# Altium schematic sheet MainBoard.SchDoc
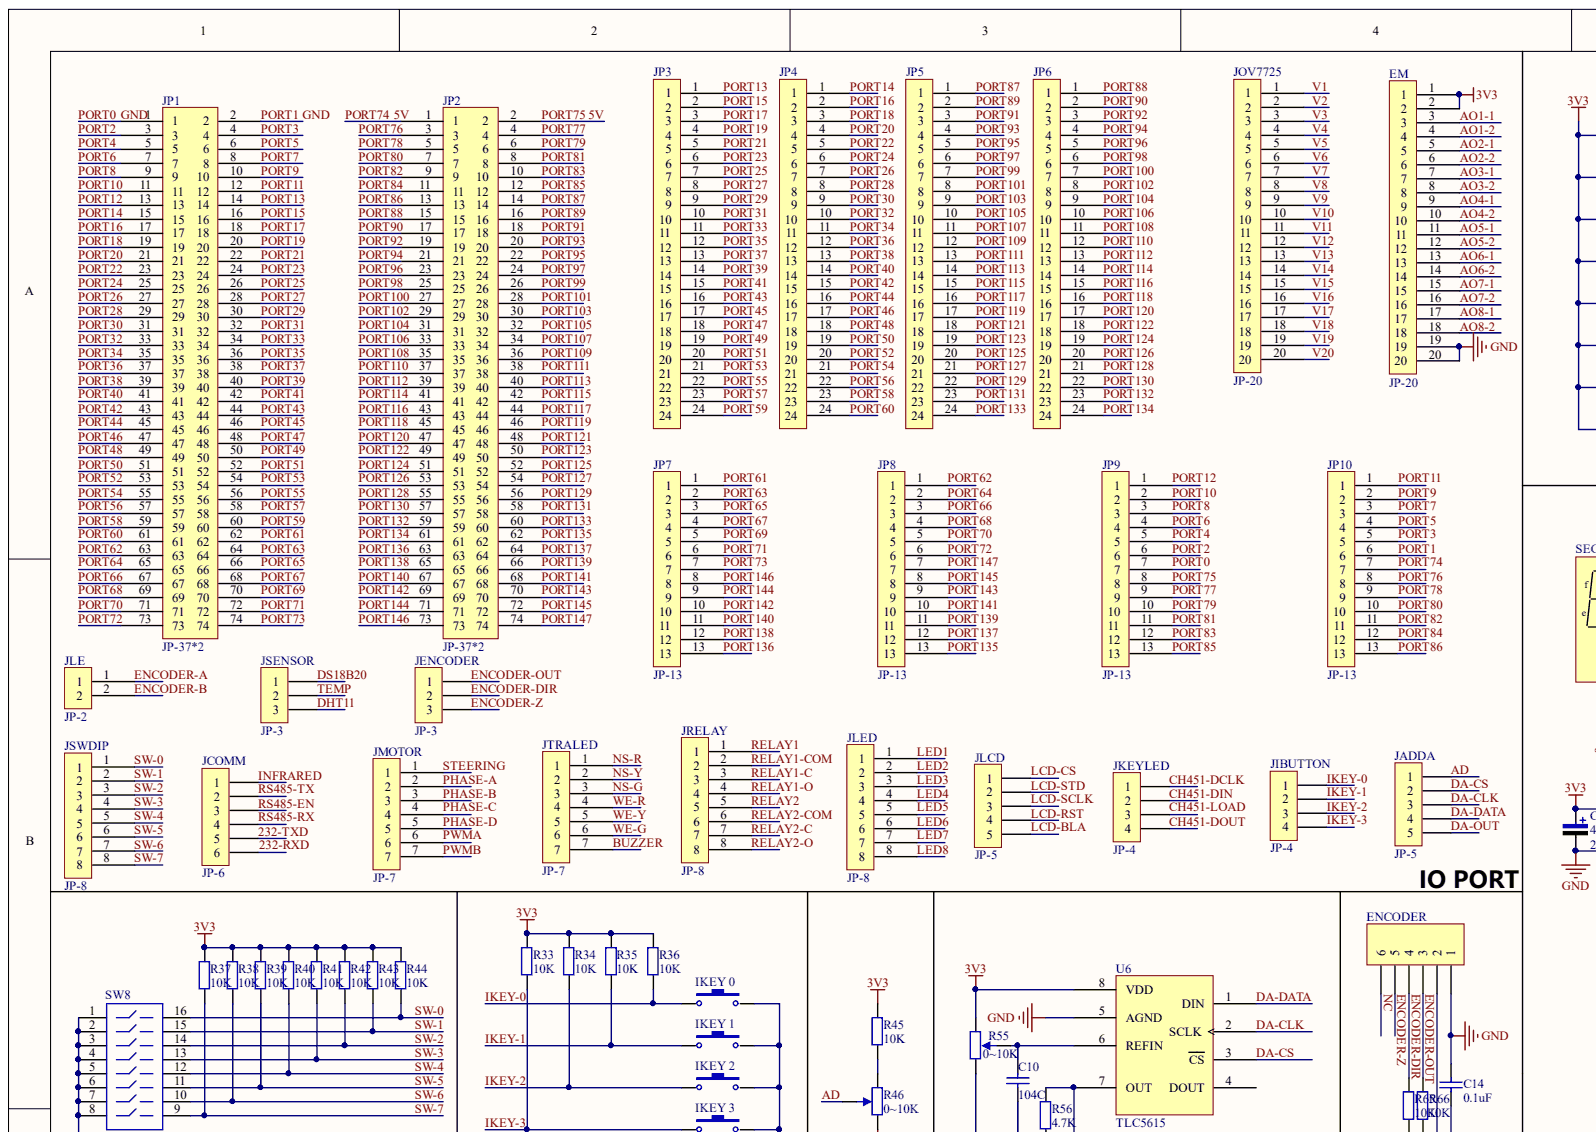
[diagram p0: MainBoard.SchDoc, top left]
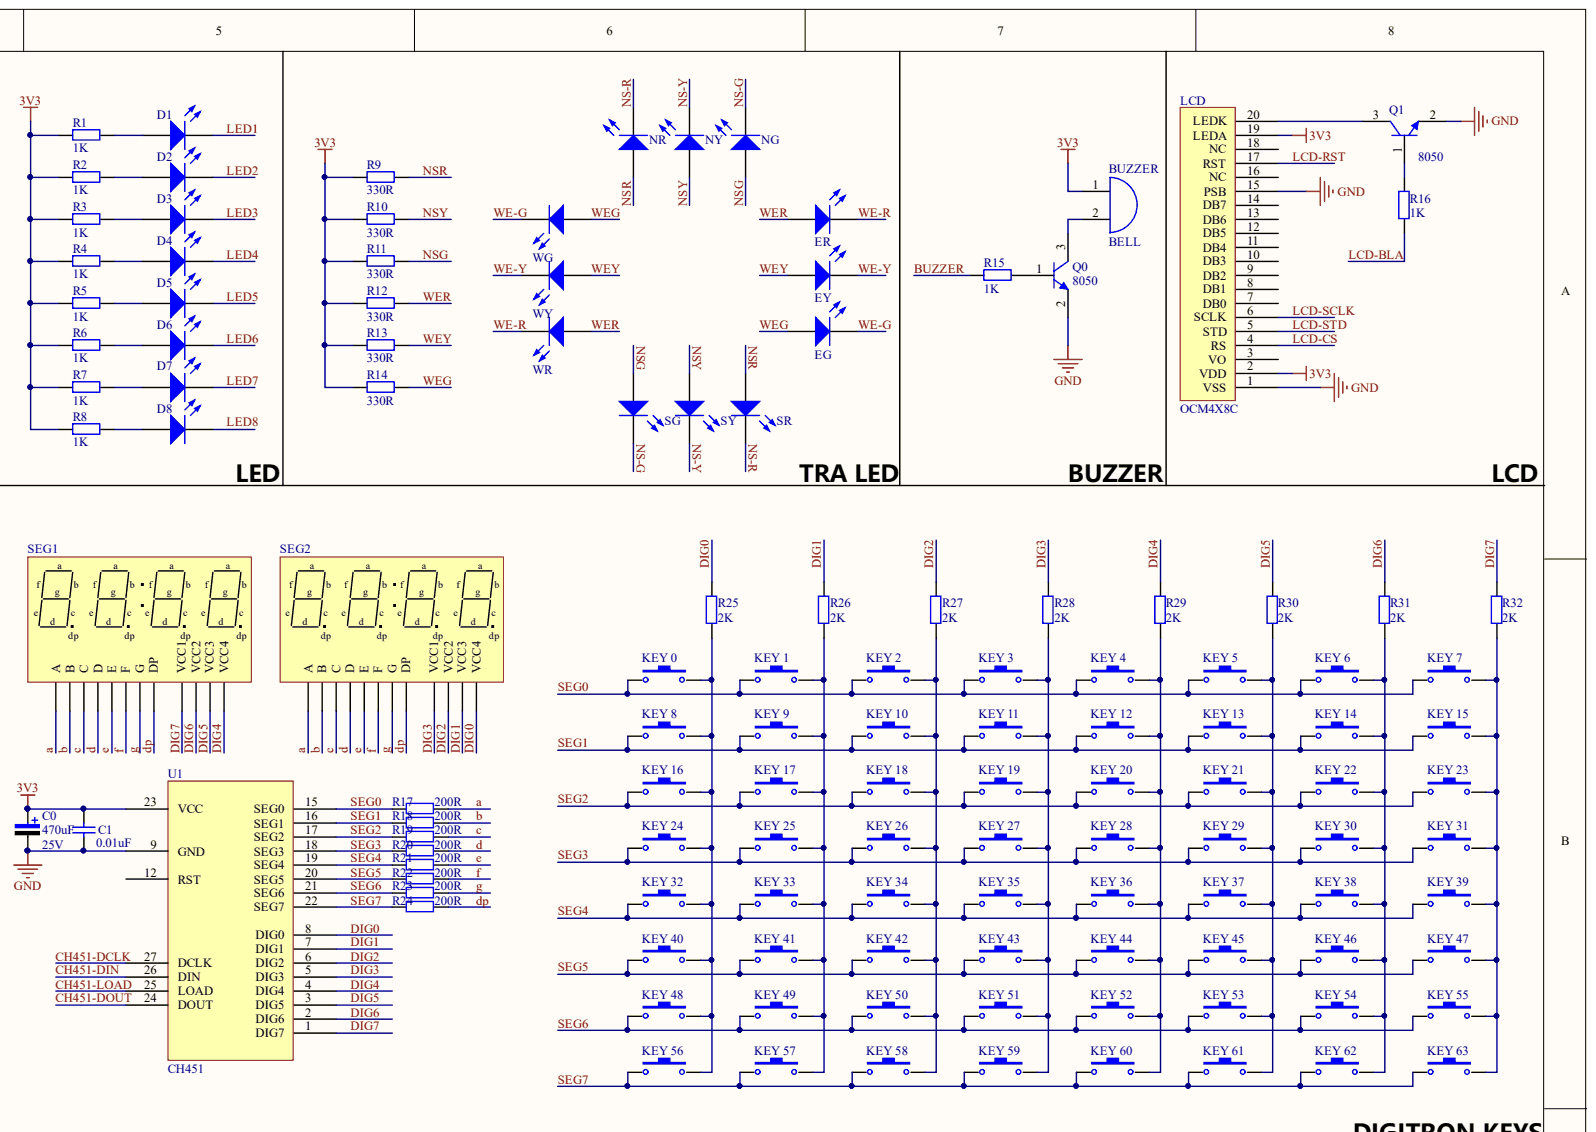
[diagram p1: MainBoard.SchDoc, top right]
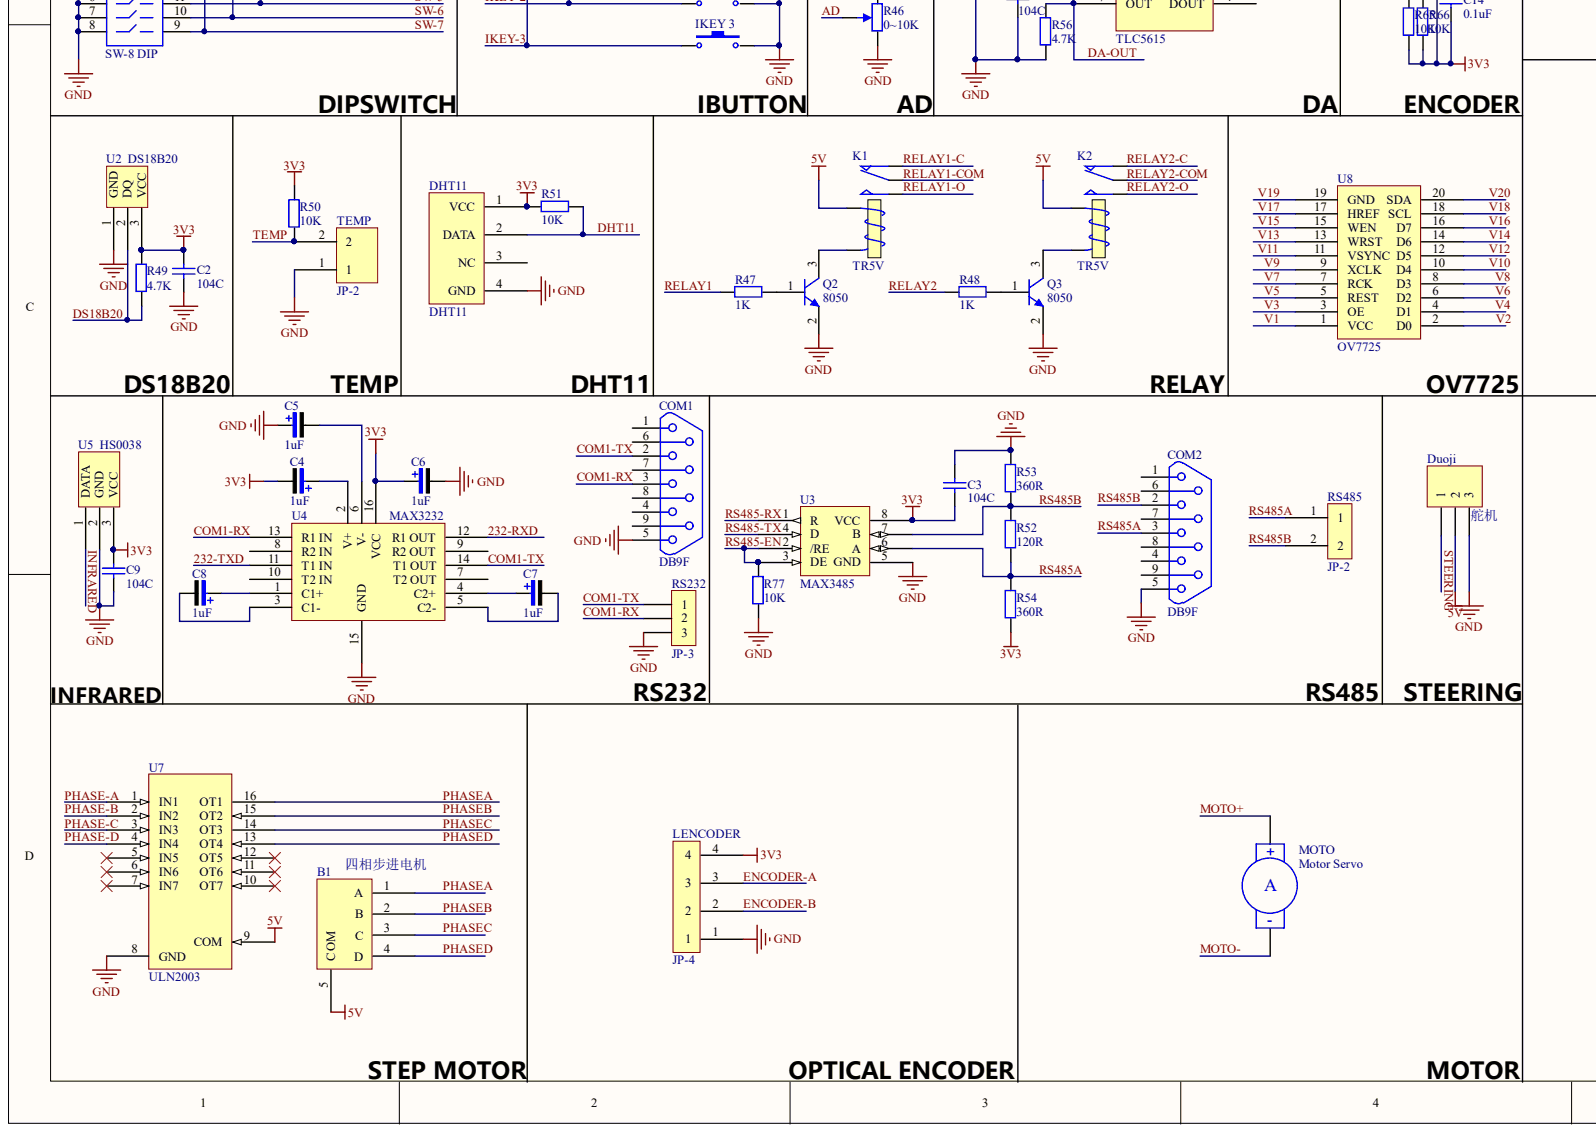
[diagram p2: MainBoard.SchDoc, bottom left]
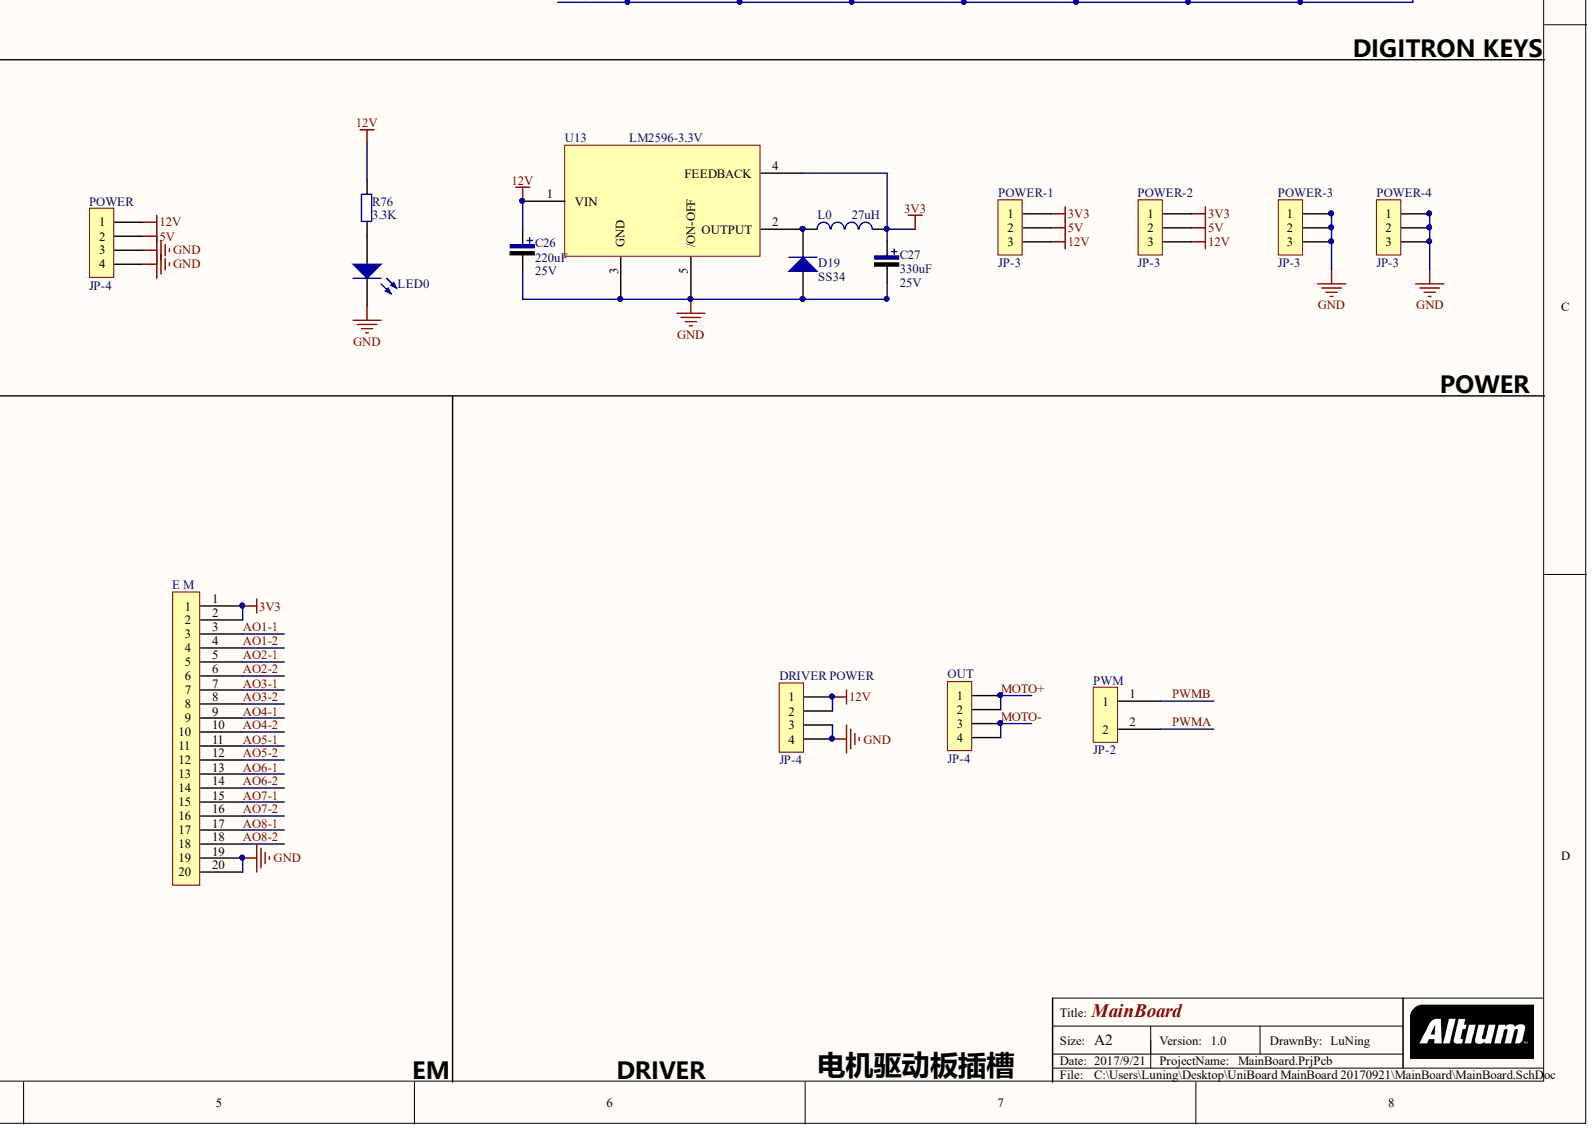
[diagram p3: MainBoard.SchDoc, bottom right]

## Parts
B1  四相步进电机  [SIP5]
BUZZER  BELL  [BUZZ0.25]
C0  value 470uF  Vol: 25V  [Cap_10uf]  (hidden comment: Cap Pol_1)
C1  value 0.01uF  [C-RAD-0805]  (hidden comment: Cap)
C2  value 104C  [C-RAD-0805]  (hidden comment: Cap)
C3  value 104C  [C-RAD-0805]  (hidden comment: Cap)
C4  value 1uF  [钽电容-A]  (hidden comment: Cap Pol)
C5  value 1uF  [钽电容-A]  (hidden comment: Cap Pol)
C6  value 1uF  [钽电容-A]  (hidden comment: Cap Pol)
C7  value 1uF  [钽电容-A]  (hidden comment: Cap Pol)
C8  value 1uF  [钽电容-A]  (hidden comment: Cap Pol)
C9  value 104C  [C-RAD-0805]  (hidden comment: Cap)
C10  value 104C  [C-RAD-0805]  (hidden comment: Cap)
C14  value 0.1uF  [C-RAD-0805]  (hidden comment: Cap)
C26  value 220uF  Vol: 25V  [Cap_10uf]  (hidden comment: Cap Pol_1)
C27  value 330uF  Vol: 25V  [Cap_10uf]  (hidden comment: Cap Pol_1)
COM1  DB9F  [DB9F]
COM2  DB9F  [DB9F]
D1  LED  [LED_0805]
D2  LED  [LED_0805]
D3  LED  [LED_0805]
D4  LED  [LED_0805]
D5  LED  [LED_0805]
D6  LED  [LED_0805]
D7  LED  [LED_0805]
D8  LED  [LED_0805]
D19  SS34  [DIODE-SMB]
DHT11  DHT11  [SIP4]
DRIVER_POWER  JP-4  [SIP4]
Duoji  舵机  [SIP3-A]
E_M  JP-20  [SIP20 - 2]  (value not drawn)
EG  LED  [LED_0805]  (value not drawn)
EM  JP-20  [K2-20 - S - D -7]
ENCODER  JP-6  [SIP6]  (value not drawn)
ER  LED  [LED_0805]  (value not drawn)
EY  LED  [LED_0805]  (value not drawn)
IKEY_0  Button -4  [SW-4]  (value not drawn)
IKEY_1  Button -4  [SW-4]  (value not drawn)
IKEY_2  Button -4  [SW-4]  (value not drawn)
IKEY_3  Button -4  [SW-4]  (value not drawn)
JADDA  JP-5  [K2-5 - S - 7]
JCOMM  JP-6  [K2-6 - S - 7]
JENCODER  JP-3  [K2-3 - S - 7]
JIBUTTON  JP-4  [K2-4 - S - 7]
JKEYLED  JP-4  [K2-4 - S - 7]
JLCD  JP-5  [K2-5 - S - 7]
JLE  JP-2  [K2-2 - S - 7]
JLED  JP-8  [K2-8 - S - 7]
JMOTOR  JP-7  [K2-7 - S - 7]
JOV7725  JP-20  [K2-20 - S - D -7]
JP1  JP-37*2  [SIP74- 2]
JP2  JP-37*2  [SIP74- 2]
JP3  JP-24  [K2-24 - S - 7]  (value not drawn)
JP4  JP-24  [K2-24 - S - 7]  (value not drawn)
JP5  JP-24  [K2-24 - S - 7]  (value not drawn)
JP6  JP-24  [K2-24 - S - 7]  (value not drawn)
JP7  JP-13  [K2-13 - S - 7]
JP8  JP-13  [K2-13 - S - 7]
JP9  JP-13  [K2-13 - S - 7]
JP10  JP-13  [K2-13 - S - 7]
JRELAY  JP-8  [K2-8 - S - 7]
JSENSOR  JP-3  [K2-3 - S - 7]
JSWDIP  JP-8  [K2-8 - S - 7]
JTRALED  JP-7  [K2-7 - S - 7]
K1  TR5V  [RELAY-5]
K2  TR5V  [RELAY-5]
KEY_0  0  [SW-4]
KEY_1  0  [SW-4]  (value not drawn)
KEY_2  0  [SW-4]  (value not drawn)
KEY_3  0  [SW-4]  (value not drawn)
KEY_4  0  [SW-4]  (value not drawn)
KEY_5  0  [SW-4]  (value not drawn)
KEY_6  0  [SW-4]  (value not drawn)
KEY_7  0  [SW-4]  (value not drawn)
KEY_8  0  [SW-4]  (value not drawn)
KEY_9  0  [SW-4]  (value not drawn)
KEY_10  0  [SW-4]  (value not drawn)
KEY_11  0  [SW-4]  (value not drawn)
KEY_12  0  [SW-4]  (value not drawn)
KEY_13  0  [SW-4]  (value not drawn)
KEY_14  0  [SW-4]  (value not drawn)
KEY_15  0  [SW-4]  (value not drawn)
KEY_16  0  [SW-4]  (value not drawn)
KEY_17  0  [SW-4]  (value not drawn)
KEY_18  0  [SW-4]  (value not drawn)
KEY_19  0  [SW-4]  (value not drawn)
KEY_20  0  [SW-4]  (value not drawn)
KEY_21  0  [SW-4]  (value not drawn)
KEY_22  0  [SW-4]  (value not drawn)
KEY_23  0  [SW-4]  (value not drawn)
KEY_24  0  [SW-4]  (value not drawn)
KEY_25  0  [SW-4]  (value not drawn)
KEY_26  0  [SW-4]  (value not drawn)
KEY_27  0  [SW-4]  (value not drawn)
KEY_28  0  [SW-4]  (value not drawn)
KEY_29  0  [SW-4]  (value not drawn)
KEY_30  0  [SW-4]  (value not drawn)
KEY_31  0  [SW-4]  (value not drawn)
KEY_32  0  [SW-4]  (value not drawn)
KEY_33  0  [SW-4]  (value not drawn)
KEY_34  0  [SW-4]  (value not drawn)
KEY_35  0  [SW-4]  (value not drawn)
KEY_36  0  [SW-4]  (value not drawn)
KEY_37  0  [SW-4]  (value not drawn)
KEY_38  0  [SW-4]  (value not drawn)
KEY_39  0  [SW-4]  (value not drawn)
KEY_40  0  [SW-4]  (value not drawn)
KEY_41  0  [SW-4]  (value not drawn)
KEY_42  0  [SW-4]  (value not drawn)
KEY_43  0  [SW-4]  (value not drawn)
KEY_44  0  [SW-4]  (value not drawn)
KEY_45  0  [SW-4]  (value not drawn)
KEY_46  0  [SW-4]  (value not drawn)
KEY_47  0  [SW-4]  (value not drawn)
KEY_48  0  [SW-4]  (value not drawn)
KEY_49  0  [SW-4]  (value not drawn)
KEY_50  0  [SW-4]  (value not drawn)
KEY_51  0  [SW-4]  (value not drawn)
KEY_52  0  [SW-4]  (value not drawn)
KEY_53  0  [SW-4]  (value not drawn)
KEY_54  0  [SW-4]  (value not drawn)
KEY_55  0  [SW-4]  (value not drawn)
KEY_56  0  [SW-4]  (value not drawn)
KEY_57  0  [SW-4]  (value not drawn)
KEY_58  0  [SW-4]  (value not drawn)
KEY_59  0  [SW-4]  (value not drawn)
KEY_60  0  [SW-4]  (value not drawn)
KEY_61  0  [SW-4]  (value not drawn)
KEY_62  0  [SW-4]  (value not drawn)
KEY_63  0  [SW-4]  (value not drawn)
L0  value 27uH  [Inductor]  (hidden comment: Inductor_1)
LCD  OCM4X8C  [SIP20]
LED0  LED  [LED_0805]
LENCODER  JP-4  [SIP4-A]
MOTO  Motor Servo  [接线柱]
NG  LED  [LED_0805]  (value not drawn)
NR  LED  [LED_0805]  (value not drawn)
NY  LED  [LED_0805]  (value not drawn)
OUT  JP-4  [SIP4]
POWER  JP-4  [SIP4-L]
POWER-1  JP-3  [K2-3 - S - s]
POWER-2  JP-3  [K2-3 - S - s]
POWER-3  JP-3  [K2-3 - S - s]
POWER-4  JP-3  [K2-3 - S - s]
PWM  JP-2  [SIP2]
Q0  8050  [SOT23_N]
Q1  8050  [SOT23_N]
Q2  8050  [SOT23_N]
Q3  8050  [SOT23_N]
R1  value 1K  [0805]  (hidden comment: resistor)
R2  value 1K  [0805]  (hidden comment: resistor)
R3  value 1K  [0805]  (hidden comment: resistor)
R4  value 1K  [0805]  (hidden comment: resistor)
R5  value 1K  [0805]  (hidden comment: resistor)
R6  value 1K  [0805]  (hidden comment: resistor)
R7  value 1K  [0805]  (hidden comment: resistor)
R8  value 1K  [0805]  (hidden comment: resistor)
R9  value 330R  [0805]  (hidden comment: resistor)
R10  value 330R  [0805]  (hidden comment: resistor)
R11  value 330R  [0805]  (hidden comment: resistor)
R12  value 330R  [0805]  (hidden comment: resistor)
R13  value 330R  [0805]  (hidden comment: resistor)
R14  value 330R  [0805]  (hidden comment: resistor)
R15  value 1K  [0805]  (hidden comment: resistor)
R16  value 1K  [0805]  (hidden comment: resistor)
R17  value 200R  [0805]  (hidden comment: resistor)
R18  value 200R  [0805]  (hidden comment: resistor)
R19  value 200R  [0805]  (hidden comment: resistor)
R20  value 200R  [0805]  (hidden comment: resistor)
R21  value 200R  [0805]  (hidden comment: resistor)
R22  value 200R  [0805]  (hidden comment: resistor)
R23  value 200R  [0805]  (hidden comment: resistor)
R24  value 200R  [0805]  (hidden comment: resistor)
R25  value 2K  [0805]  (hidden comment: resistor)
R26  value 2K  [0805]  (hidden comment: resistor)
R27  value 2K  [0805]  (hidden comment: resistor)
R28  value 2K  [0805]  (hidden comment: resistor)
R29  value 2K  [0805]  (hidden comment: resistor)
R30  value 2K  [0805]  (hidden comment: resistor)
R31  value 2K  [0805]  (hidden comment: resistor)
R32  value 2K  [0805]  (hidden comment: resistor)
R33  value 10K  [0805]  (hidden comment: resistor)
R34  value 10K  [0805]  (hidden comment: resistor)
R35  value 10K  [0805]  (hidden comment: resistor)
R36  value 10K  [0805]  (hidden comment: resistor)
R37  value 10K  [0805]  (hidden comment: resistor)
R38  value 10K  [0805]  (hidden comment: resistor)
R39  value 10K  [0805]  (hidden comment: resistor)
R40  value 10K  [0805]  (hidden comment: resistor)
R41  value 10K  [0805]  (hidden comment: resistor)
R42  value 10K  [0805]  (hidden comment: resistor)
R43  value 10K  [0805]  (hidden comment: resistor)
R44  value 10K  [0805]  (hidden comment: resistor)
R45  value 10K  [0805]  (hidden comment: resistor)
R46  value 0~10K  [SIP3 - resadj]  (hidden comment: resistor -adj)
R47  value 1K  [0805]  (hidden comment: resistor)
R48  value 1K  [0805]  (hidden comment: resistor)
R49  value 4.7K  [0805]  (hidden comment: resistor)
R50  value 10K  [0805]  (hidden comment: resistor)
R51  value 10K  [0805]  (hidden comment: resistor)
R52  value 120R  [0805]  (hidden comment: resistor)
R53  value 360R  [0805]  (hidden comment: resistor)
R54  value 360R  [0805]  (hidden comment: resistor)
R55  value 0~10K  [SIP3 - resadj]  (hidden comment: resistor -adj)
R56  value 4.7K  [0805]  (hidden comment: resistor)
R65  value 10K  [0805]  (hidden comment: resistor)
R66  value 10K  [0805]  (hidden comment: resistor)
R76  value 3.3K  [0805]  (hidden comment: resistor)
R77  value 10K  [0805]  (hidden comment: resistor)
RS232  JP-3  [接线柱 - 3]
RS485  JP-2  [接线柱 - 2]
SEG1  DPY_7-SEG_DPx4_2  [LED_8.8.:8.8.]  (value not drawn)
SEG2  DPY_7-SEG_DPx4_2  [LED_8.8.:8.8.]  (value not drawn)
SG  LED  [LED_0805]  (value not drawn)
SR  LED  [LED_0805]
SW8  SW-8 DIP  [SW-8 DIP]
SY  LED  [LED_0805]  (value not drawn)
TEMP  JP-2  [SIP2]
U1  CH451  [SOP28]
U2  DS18B20  [DS18B20]
U3  MAX3485  [SOP8_N]
U4  MAX3232  [sop16]
U5  HS0038  [HS0038]
U6  TLC5615  [SOP8_N]
U7  ULN2003  [sop16]
U8  OV7725  [SIP20 - OV7725]
U13  LM2596-3.3V  [TO-263-5]
WG  LED  [LED_0805]  (value not drawn)
WR  LED  [LED_0805]  (value not drawn)
WY  LED  [LED_0805]  (value not drawn)

## Nets
3V3: BUZZER.1 C0.1 C1.2 C2.2 C3.1 C4.2 C6.1 C9.2 C14.1 C27.1 DHT11.1(VCC) E_M.1 E_M.2 EM.1 EM.2 ENCODER.2 L0.2 LCD.2(VDD) LCD.19(LEDA) LENCODER.4 POWER-1.1 POWER-2.1 R1.1 R2.1 R3.1 R4.1 R5.1 R6.1 R7.1 R8.1 R9.1 R10.1 R11.1 R12.1 R13.1 R14.1 R33.2 R34.2 R35.2 R36.2 R37.2 R38.2 R39.2 R40.2 R41.2 R42.2 R43.2 R44.2 R45.2 R49.2 R50.2 R51.2 R54.1 R55.3 R65.2 R66.2 U1.23(VCC) U2.3 U3.8(VCC) U4.16(VCC) U5.3 U6.8(VDD) U13.4(FEEDBACK)
12V: C26.1 DRIVER_POWER.1 DRIVER_POWER.2 POWER.1 POWER-1.3 POWER-2.3 R76.1 U13.1(VIN)
5V: B1.5(COM) Duoji.2 JP2.1 JP2.2 JP9.8 JP10.7 K1.2 K2.2 POWER.2 POWER-1.2 POWER-2.2 U7.9(COM)
232-RXD: JCOMM.6 U4.12(R1_OUT)
232-TXD: JCOMM.5 U4.11(T1_IN)
A: R17.2 SEG1.11(A) SEG2.11(A)
AD: JADDA.1 R46.2
AO1-1: E_M.3 EM.3
AO1-2: E_M.4 EM.4
AO2-1: E_M.5 EM.5
AO2-2: E_M.6 EM.6
AO3-1: E_M.7 EM.7
AO3-2: E_M.8 EM.8
AO4-1: E_M.9 EM.9
AO4-2: E_M.10 EM.10
AO5-1: E_M.11 EM.11
AO5-2: E_M.12 EM.12
AO6-1: E_M.13 EM.13
AO6-2: E_M.14 EM.14
AO7-1: E_M.15 EM.15
AO7-2: E_M.16 EM.16
AO8-1: E_M.17 EM.17
AO8-2: E_M.18 EM.18
B: R18.2 SEG1.7(B) SEG2.7(B)
BUZZER: JTRALED.7 R15.1
C: R19.2 SEG1.4(C) SEG2.4(C)
CH451-DCLK: JKEYLED.1 U1.27(DCLK)
CH451-DIN: JKEYLED.2 U1.26(DIN)
CH451-DOUT: JKEYLED.4 U1.24(DOUT)
CH451-LOAD: JKEYLED.3 U1.25(LOAD)
COM1-RX: COM1.3 RS232.2 U4.13(R1_IN)
COM1-TX: COM1.2 RS232.1 U4.14(T1_OUT)
D: R20.2 SEG1.2(D) SEG2.2(D)
DA-CLK: JADDA.3 U6.2(SCLK)
DA-CS: JADDA.2 U6.3(CS)
DA-DATA: JADDA.4 U6.1(DIN)
DA-OUT: JADDA.5 R56.2 U6.7(OUT)
DHT11: DHT11.2(DATA) JSENSOR.3 R51.1
DIG0: R25.2 SEG2.6(VCC4) U1.8(DIG0)
DIG1: R26.2 SEG2.8(VCC3) U1.7(DIG1)
DIG2: R27.2 SEG2.9(VCC2) U1.6(DIG2)
DIG3: R28.2 SEG2.12(VCC1_dp) U1.5(DIG3)
DIG4: R29.2 SEG1.6(VCC4) U1.4(DIG4)
DIG5: R30.2 SEG1.8(VCC3) U1.3(DIG5)
DIG6: R31.2 SEG1.9(VCC2) U1.2(DIG6)
DIG7: R32.2 SEG1.12(VCC1) U1.1(DIG7)
DP: R24.2 SEG1.3(DP) SEG2.3(DP)
DS18B20: JSENSOR.1 R49.1 U2.2
E: R21.2 SEG1.1(E) SEG2.1(E)
ENCODER-A: JLE.1 LENCODER.3
ENCODER-B: JLE.2 LENCODER.2
ENCODER-DIR: ENCODER.4 JENCODER.2 R65.1
ENCODER-OUT: ENCODER.3 JENCODER.1 R66.1
ENCODER-Z: ENCODER.5 JENCODER.3
F: R22.2 SEG1.10(F) SEG2.10(F)
G: R23.2 SEG1.5(G) SEG2.5(G)
GND: C0.2 C1.1 C2.1 C3.2 C5.1 C6.2 C9.1 C10.1 C14.2 C26.2 C27.2 COM1.5 COM2.5 D19.1 DHT11.4(GND) DRIVER_POWER.3 DRIVER_POWER.4 Duoji.3 E_M.19 E_M.20 EM.19 EM.20 ENCODER.1 IKEY_0.2 IKEY_1.2 IKEY_2.2 IKEY_3.2 JP1.1 JP1.2 JP9.7 JP10.6 LCD.1(VSS) LCD.15(PSB) LED0.2 LENCODER.1 POWER.3 POWER.4 POWER-3.1 POWER-3.2 POWER-3.3 POWER-4.1 POWER-4.2 POWER-4.3 Q0.2 Q1.2 Q2.2 Q3.2 R46.3 R53.2 R55.1 R56.1 R77.1 RS232.3 SW8.1 SW8.2 SW8.3 SW8.4 SW8.5 SW8.6 SW8.7 SW8.8 TEMP.1 U1.9(GND) U2.1 U3.5(GND) U4.15(GND) U5.2 U6.5(AGND) U7.8(GND) U13.3(GND) U13.5(/ON-OFF)
IKEY-0: IKEY_0.1 JIBUTTON.1 R36.1
IKEY-1: IKEY_1.1 JIBUTTON.2 R35.1
IKEY-2: IKEY_2.1 JIBUTTON.3 R34.1
IKEY-3: IKEY_3.1 JIBUTTON.4 R33.1
INFRARED: JCOMM.1 U5.1
LCD-BLA: JLCD.5 R16.1
LCD-CS: JLCD.1 LCD.4(RS)
LCD-RST: JLCD.4 LCD.17(RST)
LCD-SCLK: JLCD.3 LCD.6(SCLK)
LCD-STD: JLCD.2 LCD.5(STD)
LED1: D1.2 JLED.1
LED2: D2.2 JLED.2
LED3: D3.2 JLED.3
LED4: D4.2 JLED.4
LED5: D5.2 JLED.5
LED6: D6.2 JLED.6
LED7: D7.2 JLED.7
LED8: D8.2 JLED.8
MOTO+: MOTO.1 OUT.1 OUT.2
MOTO-: MOTO.2 OUT.3 OUT.4
NC: ENCODER.6
NS-G: JTRALED.3 NG.2 SG.2
NS-R: JTRALED.1 NR.2 SR.2
NS-Y: JTRALED.2 NY.2 SY.2
NSG: NG.1 R11.2 SG.1
NSR: NR.1 R9.2 SR.1
NSY: NY.1 R10.2 SY.1
PHASE-A: JMOTOR.2 U7.1(IN1)
PHASE-B: JMOTOR.3 U7.2(IN2)
PHASE-C: JMOTOR.4 U7.3(IN3)
PHASE-D: JMOTOR.5 U7.4(IN4)
PHASEA: B1.1(A) U7.16(OT1)
PHASEB: B1.2(B) U7.15(OT2)
PHASEC: B1.3(C) U7.14(OT3)
PHASED: B1.4(D) U7.13(OT4)
PORT2: JP1.3 JP9.6
PORT3: JP1.4 JP10.5
PORT4: JP1.5 JP9.5
PORT5: JP1.6 JP10.4
PORT6: JP1.7 JP9.4
PORT7: JP1.8 JP10.3
PORT8: JP1.9 JP9.3
PORT9: JP1.10 JP10.2
PORT10: JP1.11 JP9.2
PORT11: JP1.12 JP10.1
PORT12: JP1.13 JP9.1
PORT13: JP1.14 JP3.1
PORT14: JP1.15 JP4.1
PORT15: JP1.16 JP3.2
PORT16: JP1.17 JP4.2
PORT17: JP1.18 JP3.3
PORT18: JP1.19 JP4.3
PORT19: JP1.20 JP3.4
PORT20: JP1.21 JP4.4
PORT21: JP1.22 JP3.5
PORT22: JP1.23 JP4.5
PORT23: JP1.24 JP3.6
PORT24: JP1.25 JP4.6
PORT25: JP1.26 JP3.7
PORT26: JP1.27 JP4.7
PORT27: JP1.28 JP3.8
PORT28: JP1.29 JP4.8
PORT29: JP1.30 JP3.9
PORT30: JP1.31 JP4.9
PORT31: JP1.32 JP3.10
PORT32: JP1.33 JP4.10
PORT33: JP1.34 JP3.11
PORT34: JP1.35 JP4.11
PORT35: JP1.36 JP3.12
PORT36: JP1.37 JP4.12
PORT37: JP1.38 JP3.13
PORT38: JP1.39 JP4.13
PORT39: JP1.40 JP3.14
PORT40: JP1.41 JP4.14
PORT41: JP1.42 JP3.15
PORT42: JP1.43 JP4.15
PORT43: JP1.44 JP3.16
PORT44: JP1.45 JP4.16
PORT45: JP1.46 JP3.17
PORT46: JP1.47 JP4.17
PORT47: JP1.48 JP3.18
PORT48: JP1.49 JP4.18
PORT49: JP1.50 JP3.19
PORT50: JP1.51 JP4.19
PORT51: JP1.52 JP3.20
PORT52: JP1.53 JP4.20
PORT53: JP1.54 JP3.21
PORT54: JP1.55 JP4.21
PORT55: JP1.56 JP3.22
PORT56: JP1.57 JP4.22
PORT57: JP1.58 JP3.23
PORT58: JP1.59 JP4.23
PORT59: JP1.60 JP3.24
PORT60: JP1.61 JP4.24
PORT61: JP1.62 JP7.1
PORT62: JP1.63 JP8.1
PORT63: JP1.64 JP7.2
PORT64: JP1.65 JP8.2
PORT65: JP1.66 JP7.3
PORT66: JP1.67 JP8.3
PORT67: JP1.68 JP7.4
PORT68: JP1.69 JP8.4
PORT69: JP1.70 JP7.5
PORT70: JP1.71 JP8.5
PORT71: JP1.72 JP7.6
PORT72: JP1.73 JP8.6
PORT73: JP1.74 JP7.7
PORT76: JP2.3 JP10.8
PORT77: JP2.4 JP9.9
PORT78: JP2.5 JP10.9
PORT79: JP2.6 JP9.10
PORT80: JP2.7 JP10.10
PORT81: JP2.8 JP9.11
PORT82: JP2.9 JP10.11
PORT83: JP2.10 JP9.12
PORT84: JP2.11 JP10.12
PORT85: JP2.12 JP9.13
PORT86: JP2.13 JP10.13
PORT87: JP2.14 JP5.1
PORT88: JP2.15 JP6.1
PORT89: JP2.16 JP5.2
PORT90: JP2.17 JP6.2
PORT91: JP2.18 JP5.3
PORT92: JP2.19 JP6.3
PORT93: JP2.20 JP5.4
PORT94: JP2.21 JP6.4
PORT95: JP2.22 JP5.5
PORT96: JP2.23 JP6.5
PORT97: JP2.24 JP5.6
PORT98: JP2.25 JP6.6
PORT99: JP2.26 JP5.7
PORT100: JP2.27 JP6.7
PORT101: JP2.28 JP5.8
PORT102: JP2.29 JP6.8
PORT103: JP2.30 JP5.9
PORT104: JP2.31 JP6.9
PORT105: JP2.32 JP5.10
PORT106: JP2.33 JP6.10
PORT107: JP2.34 JP5.11
PORT108: JP2.35 JP6.11
PORT109: JP2.36 JP5.12
PORT110: JP2.37 JP6.12
PORT111: JP2.38 JP5.13
PORT112: JP2.39 JP6.13
PORT113: JP2.40 JP5.14
PORT114: JP2.41 JP6.14
PORT115: JP2.42 JP5.15
PORT116: JP2.43 JP6.15
PORT117: JP2.44 JP5.16
PORT118: JP2.45 JP6.16
PORT119: JP2.46 JP5.17
PORT120: JP2.47 JP6.17
PORT121: JP2.48 JP5.18
PORT122: JP2.49 JP6.18
PORT123: JP2.50 JP5.19
PORT124: JP2.51 JP6.19
PORT125: JP2.52 JP5.20
PORT126: JP2.53 JP6.20
PORT127: JP2.54 JP5.21
PORT128: JP2.55 JP6.21
PORT129: JP2.56 JP5.22
PORT130: JP2.57 JP6.22
PORT131: JP2.58 JP5.23
PORT132: JP2.59 JP6.23
PORT133: JP2.60 JP5.24
PORT134: JP2.61 JP6.24
PORT135: JP2.62 JP8.13
PORT136: JP2.63 JP7.13
PORT137: JP2.64 JP8.12
PORT138: JP2.65 JP7.12
PORT139: JP2.66 JP8.11
PORT140: JP2.67 JP7.11
PORT141: JP2.68 JP8.10
PORT142: JP2.69 JP7.10
PORT143: JP2.70 JP8.9
PORT144: JP2.71 JP7.9
PORT145: JP2.72 JP8.8
PORT146: JP2.73 JP7.8
PORT147: JP2.74 JP8.7
PWMA: JMOTOR.6 PWM.2
PWMB: JMOTOR.7 PWM.1
RELAY1: JRELAY.1 R47.1
RELAY1-C: JRELAY.3 K1.1
RELAY1-COM: JRELAY.2 K1.5
RELAY1-O: JRELAY.4 K1.10
RELAY2: JRELAY.5 R48.1
RELAY2-C: JRELAY.7 K2.1
RELAY2-COM: JRELAY.6 K2.5
RELAY2-O: JRELAY.8 K2.10
RS485-EN: JCOMM.3 R77.2 U3.2(/RE) U3.3(DE)
RS485-RX: JCOMM.4 U3.1(R)
RS485-TX: JCOMM.2 U3.4(D)
RS485A: COM2.3 R52.1 R54.2 RS485.1 U3.6(A)
RS485B: COM2.2 R52.2 R53.1 RS485.2 U3.7(B)
SEG0: KEY_0.1 KEY_1.1 KEY_2.1 KEY_3.1 KEY_4.1 KEY_5.1 KEY_6.1 KEY_7.1 R17.1 U1.15(SEG0)
SEG1: KEY_8.1 KEY_9.1 KEY_10.1 KEY_11.1 KEY_12.1 KEY_13.1 KEY_14.1 KEY_15.1 R18.1 U1.16(SEG1)
SEG2: KEY_16.1 KEY_17.1 KEY_18.1 KEY_19.1 KEY_20.1 KEY_21.1 KEY_22.1 KEY_23.1 R19.1 U1.17(SEG2)
SEG3: KEY_24.1 KEY_25.1 KEY_26.1 KEY_27.1 KEY_28.1 KEY_29.1 KEY_30.1 KEY_31.1 R20.1 U1.18(SEG3)
SEG4: KEY_32.1 KEY_33.1 KEY_34.1 KEY_35.1 KEY_36.1 KEY_37.1 KEY_38.1 KEY_39.1 R21.1 U1.19(SEG4)
SEG5: KEY_40.1 KEY_41.1 KEY_42.1 KEY_43.1 KEY_44.1 KEY_45.1 KEY_46.1 KEY_47.1 R22.1 U1.20(SEG5)
SEG6: KEY_48.1 KEY_49.1 KEY_50.1 KEY_51.1 KEY_52.1 KEY_53.1 KEY_54.1 KEY_55.1 R23.1 U1.21(SEG6)
SEG7: KEY_56.1 KEY_57.1 KEY_58.1 KEY_59.1 KEY_60.1 KEY_61.1 KEY_62.1 KEY_63.1 R24.1 U1.22(SEG7)
STEERING: Duoji.1 JMOTOR.1
SW-0: JSWDIP.1 R44.1 SW8.16
SW-1: JSWDIP.2 R43.1 SW8.15
SW-2: JSWDIP.3 R42.1 SW8.14
SW-3: JSWDIP.4 R41.1 SW8.13
SW-4: JSWDIP.5 R40.1 SW8.12
SW-5: JSWDIP.6 R39.1 SW8.11
SW-6: JSWDIP.7 R38.1 SW8.10
SW-7: JSWDIP.8 R37.1 SW8.9
TEMP: JSENSOR.2 R50.1 TEMP.2
V1: JOV7725.1 U8.1(VCC)
V2: JOV7725.2 U8.2(D0)
V3: JOV7725.3 U8.3(OE)
V4: JOV7725.4 U8.4(D1)
V5: JOV7725.5 U8.5(REST)
V6: JOV7725.6 U8.6(D2)
V7: JOV7725.7 U8.7(RCK)
V8: JOV7725.8 U8.8(D3)
V9: JOV7725.9 U8.9(XCLK)
V10: JOV7725.10 U8.10(D4)
V11: JOV7725.11 U8.11(VSYNC)
V12: JOV7725.12 U8.12(D5)
V13: JOV7725.13 U8.13(WRST)
V14: JOV7725.14 U8.14(D6)
V15: JOV7725.15 U8.15(WEN)
V16: JOV7725.16 U8.16(D7)
V17: JOV7725.17 U8.17(HREF)
V18: JOV7725.18 U8.18(SCL)
V19: JOV7725.19 U8.19(GND)
V20: JOV7725.20 U8.20(SDA)
WE-G: EG.2 JTRALED.6 WG.2
WE-R: ER.2 JTRALED.4 WR.2
WE-Y: EY.2 JTRALED.5 WY.2
WEG: EG.1 R14.2 WG.1
WER: ER.1 R12.2 WR.1
WEY: EY.1 R13.2 WY.1
NetBUZZER_2: BUZZER.2 Q0.3
NetC4_1: C4.1 U4.2(V+)
NetC5_2: C5.2 U4.6(V-)
NetC7_1: C7.1 U4.4(C2+)
NetC7_2: C7.2 U4.5(C2-)
NetC8_1: C8.1 U4.1(C1+)
NetC8_2: C8.2 U4.3(C1-)
NetC10_2: C10.2 R55.2 U6.6(REFIN)
NetD1_1: D1.1 R1.2
NetD2_1: D2.1 R2.2
NetD3_1: D3.1 R3.2
NetD4_1: D4.1 R4.2
NetD5_1: D5.1 R5.2
NetD6_1: D6.1 R6.2
NetD7_1: D7.1 R7.2
NetD8_1: D8.1 R8.2
NetD19_2: D19.2 L0.1 U13.2(OUTPUT)
NetK1_9: K1.9 Q2.3
NetK2_9: K2.9 Q3.3
NetKEY 0_2: KEY_0.2 KEY_8.2 KEY_16.2 KEY_24.2 KEY_32.2 KEY_40.2 KEY_48.2 KEY_56.2 R25.1
NetKEY 1_2: KEY_1.2 KEY_9.2 KEY_17.2 KEY_25.2 KEY_33.2 KEY_41.2 KEY_49.2 KEY_57.2 R26.1
NetKEY 2_2: KEY_2.2 KEY_10.2 KEY_18.2 KEY_26.2 KEY_34.2 KEY_42.2 KEY_50.2 KEY_58.2 R27.1
NetKEY 3_2: KEY_3.2 KEY_11.2 KEY_19.2 KEY_27.2 KEY_35.2 KEY_43.2 KEY_51.2 KEY_59.2 R28.1
NetKEY 4_2: KEY_4.2 KEY_12.2 KEY_20.2 KEY_28.2 KEY_36.2 KEY_44.2 KEY_52.2 KEY_60.2 R29.1
NetKEY 5_2: KEY_5.2 KEY_13.2 KEY_21.2 KEY_29.2 KEY_37.2 KEY_45.2 KEY_53.2 KEY_61.2 R30.1
NetKEY 6_2: KEY_6.2 KEY_14.2 KEY_22.2 KEY_30.2 KEY_38.2 KEY_46.2 KEY_54.2 KEY_62.2 R31.1
NetKEY 7_2: KEY_7.2 KEY_15.2 KEY_23.2 KEY_31.2 KEY_39.2 KEY_47.2 KEY_55.2 KEY_63.2 R32.1
NetLCD_20: LCD.20(LEDK) Q1.3
NetLED0_1: LED0.1 R76.2
NetQ0_1: Q0.1 R15.2
NetQ1_1: Q1.1 R16.2
NetQ2_1: Q2.1 R47.2
NetQ3_1: Q3.1 R48.2
NetR45_1: R45.1 R46.1

Not connected: COM1 1 4 6 7 8 9; COM2 1 4 6 7 8 9; DHT11 3(NC); LCD 3(VO) 7(DB0) 8(DB1) 9(DB2) 10(DB3) 11(DB4) 12(DB5) 13(DB6) 14(DB7) 16(NC) 18(NC); U1 12(RST); U4 7(T2_OUT) 8(R2_IN) 9(R2_OUT) 10(T2_IN); U6 4(DOUT); U7 5(IN5) 6(IN6) 7(IN7) 10(OT7) 11(OT6) 12(OT5)

## Library data of the parts
BUZZER = BELL: 蜂鸣器; Component Kind: Standard; Library Name: Luning's Library.IntLib
C0 C26 C27 = Cap Pol_1: 电解电容 过孔; Component Kind: Standard; LatestRevisionDate: 17-Jul-2002; LatestRevisionNote: Re-released for DXP Platform.; PackageReference: RB7.6-15; Published: 8-Jun-2000; Library Name: Luning's Library.IntLib; Publisher: Altium Limited
C1-C3 C9 C10 C14 = Cap: 无极性电容 贴片; Component Kind: Standard; LatestRevisionDate: 17-Jul-2002; LatestRevisionNote: Re-released for DXP Platform.; PackageReference: RAD-0.3; Published: 8-Jun-2000; Library Name: Luning's Library.IntLib; Publisher: Altium Limited
C4-C8 = Cap Pol: 电解电容 贴片; Vol: 16V; Component Kind: Standard; LatestRevisionDate: 17-Jul-2002; LatestRevisionNote: Re-released for DXP Platform.; PackageReference: RB7.6-15; Published: 8-Jun-2000; Library Name: Luning's Library.IntLib; Publisher: Altium Limited
COM1 COM2 = DB9F: jointbar; Component Kind: Standard; Library Name: Luning's Library.IntLib
D1-D8 EG ER EY LED0 NG NR NY SG SR SY WG WR WY = LED: 发光二极管; Component Kind: Standard; LatestRevisionDate: 08-Jul-2005; LatestRevisionNote: Mech Layer 1 removed.; PackageReference: LED-0; Published: 8-Jun-2000; Library Name: Luning's Library.IntLib; Publisher: Altium Limited
D19 = Diode: 二极管; Component Kind: Standard; Library Name: Luning's Library.IntLib
DHT11 = DHT11: 数字温湿度传感器; Component Kind: Standard; Library Name: Luning's Library.IntLib
DRIVER_POWER JIBUTTON JKEYLED LENCODER OUT POWER = JP-4: 接线端子; Component Kind: Standard; Library Name: Luning's Library.IntLib
Duoji JENCODER JSENSOR POWER-1-POWER-4 RS232 = JP-3: 接线端子; Component Kind: Standard; Library Name: Luning's Library.IntLib
E_M EM JOV7725 = JP-20: 接线端子; Component Kind: Standard; Library Name: Luning's Library.IntLib
ENCODER JCOMM = JP-6: 接线端子; Component Kind: Standard; Library Name: Luning's Library.IntLib
IKEY_0-IKEY_3 KEY_0-KEY_63 = Button -4: 微动开关; Component Kind: Standard; Library Name: Luning's Library.IntLib
JADDA JLCD = JP-5: 接线端子; Component Kind: Standard; Library Name: Luning's Library.IntLib
JLE PWM RS485 TEMP = JP-2: 接线端子; Component Kind: Standard; Library Name: Luning's Library.IntLib
JLED JRELAY JSWDIP = JP-8: 接线端子; Component Kind: Standard; Library Name: Luning's Library.IntLib
JMOTOR JTRALED = JP-7: 接线端子; Component Kind: Standard; Library Name: Luning's Library.IntLib
JP1 JP2 = JP-37*2: 接线端子; Component Kind: Standard; Library Name: Luning's Library.IntLib
JP3-JP6 = JP-24: 接线端子; Component Kind: Standard; Library Name: Luning's Library.IntLib
JP7-JP10 = JP-13: 接线端子; Component Kind: Standard; Library Name: Luning's Library.IntLib
K1 K2 = RELAY-SPDT_TB: 单刀双置继电器; Component Kind: Standard; Library Name: Luning's Library.IntLib
L0 = Inductor_1: 电感 过孔; Code_IEC: 1005; Code_JEITA: 0402; Component Kind: Standard; LatestRevisionDate: 29-May-2009; LatestRevisionNote: IPC-7351 Footprint Added.; PackageDescription: Chip Inductor; PackageReference: 0402-A; Published: 8-Jun-2000; Library Name: Luning's Library.IntLib; Publisher: Altium Limited
LCD = OCM4X8C: 12864液晶; Component Kind: Standard; Library Name: Luning's Library.IntLib
MOTO = Motor Servo: 电机; Component Kind: Standard; LatestRevisionDate: 17-Jul-2002; LatestRevisionNote: Re-released for DXP Platform.; PackageDescription: Radial Cap, Thru-Hole; 2 Leads; 0.4 in Pin Spacing; PackageReference: RAD-0.4; Published: 24-Mar-1999; Library Name: Luning's Library.IntLib; Publisher: Altium Limited
Q0-Q3 = 8050: NPN 通用放大器; Component Kind: Standard; ComponentLink1Description: Manufacturer Link; ComponentLink1URL: http://www.fairchildsemi.com/; ComponentLink2Description: Datasheet; ComponentLink2URL: http://www.fairchildsemi.com/ds/2N%2F2N3904.pdf; DatasheetVersion: 1997; LatestRevisionDate: 15-Jan-2003; LatestRevisionNote: PCB Footprint 'BCY-W3/D4.7' replaced; PackageDescription: TO, Flat Index; 3 In-Line, Axial Leads; Body Dia. 4.5mm; Leads 0.51 x 0.48 mm (max); PackageReference: TO-92A; PackageVersion: Sep-1998; Published: 8-Jun-2000; Library Name: Luning's Library.IntLib; Publisher: Altium Limited
R1-R45 R47-R54 R56 R65 R66 R76 R77 = resistor: 电阻 贴片; Component Kind: Standard; Library Name: Luning's Library.IntLib
R46 R55 = resistor -adj: 可调电阻; Component Kind: Standard; Library Name: Luning's Library.IntLib
SEG1 SEG2 = DPY7-SEG_DPx4: 4位数码管; Component Kind: Standard; Library Name: Luning's Library.IntLib
SW8 = SW-8 DIP: 拨码开关; Component Kind: Standard; Library Name: Luning's Library.IntLib
U1 = CH451S: 按键数码管驱动; Component Kind: Standard; Library Name: Luning's Library.IntLib
U2 = DS18B20: 温度传感器; Component Kind: Standard; Library Name: Luning's Library.IntLib
U3 = MAX485: 485; Component Kind: Standard; Library Name: Luning's Library.IntLib
U4 = MAX232: Component Kind: Standard; Library Name: Luning's Library.IntLib
U5 = HS0038: 红外接收头; Component Kind: Standard; Library Name: Luning's Library.IntLib
U6 = TLC5615: DA; Component Kind: Standard; Library Name: Luning's Library.IntLib
U7 = ULN2003: 达林顿管; Component Kind: Standard; Library Name: Luning's Library.IntLib
U8 = OV7725: 摄像头接口; Component Kind: Standard; Library Name: Luning's Library.IntLib
U13 = LM2575S: 电源转换; Component Kind: Standard; Library Name: Luning's Library.IntLib
B1 = 四相步进电机: Component Kind: Standard; Description: 四相步进电机; Library Name: Luning's Library.IntLib
